# Altium schematic sheet mic_totem_ee-1_Main.SchDoc(mic_totem_ee-1_Main)
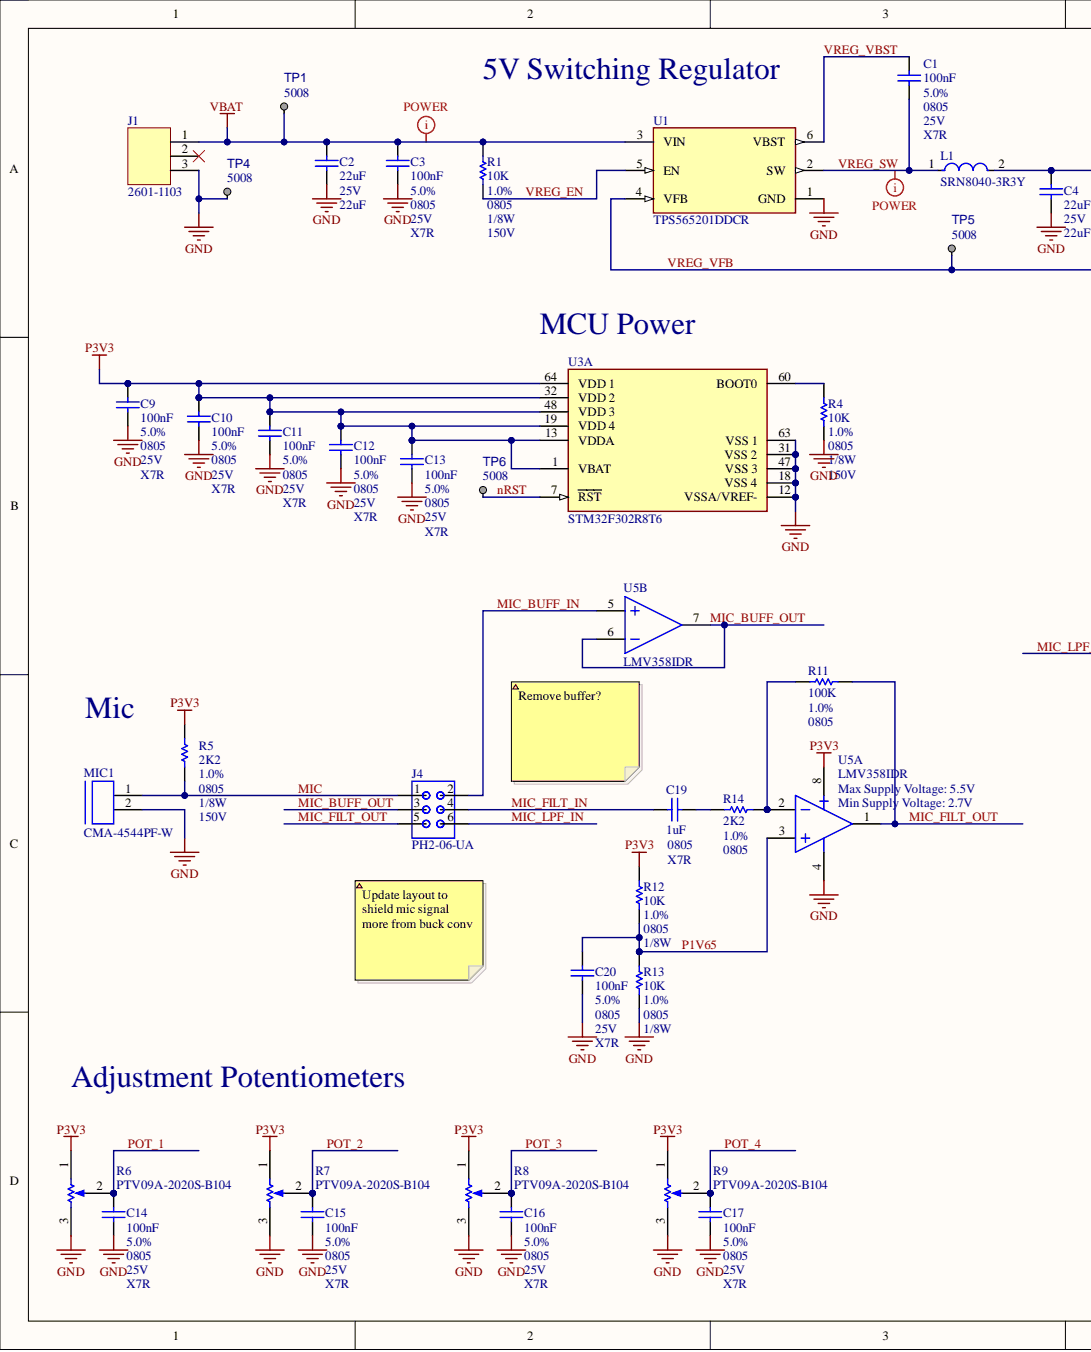
[diagram p0: mic_totem_ee-1_Main.SchDoc, left]
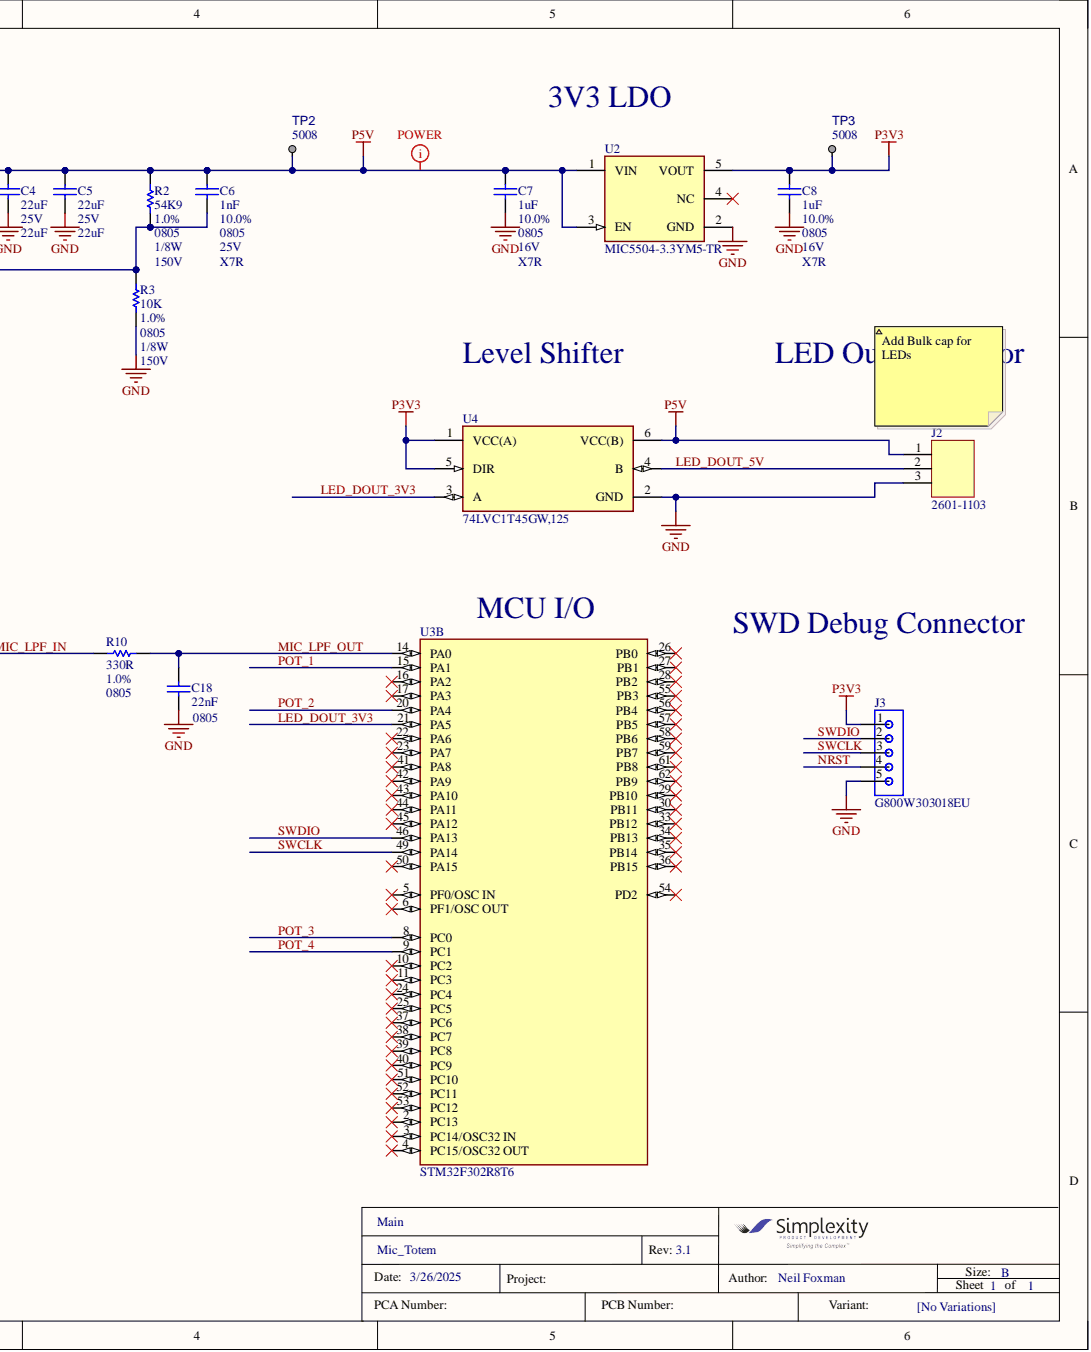
[diagram p1: mic_totem_ee-1_Main.SchDoc, right]

## Parts
C1  100nF  Rated Voltage: 25V  Tolerance: 5.0%  [0805]
C2  22uF  Voltage Rating (DC): 25V  [1206]
C3  100nF  Rated Voltage: 25V  Tolerance: 5.0%  [0805]
C4  22uF  Voltage Rating (DC): 25V  [1206]
C5  22uF  Voltage Rating (DC): 25V  [1206]
C6  1nF  Rated Voltage: 25V  Tolerance: 10.0%  [0805]
C7  1uF  Rated Voltage: 16V  Tolerance: 10.0%  [0805]
C8  1uF  Rated Voltage: 16V  Tolerance: 10.0%  [0805]
C9  100nF  Rated Voltage: 25V  Tolerance: 5.0%  [0805]
C10  100nF  Construction: X7R  Rated Voltage: 25V  Tolerance: 5.0%  [0805]
C11  100nF  Construction: X7R  Rated Voltage: 25V  Tolerance: 5.0%  [0805]
C12  100nF  Construction: X7R  Rated Voltage: 25V  Tolerance: 5.0%  [0805]
C13  100nF  Construction: X7R  Rated Voltage: 25V  Tolerance: 5.0%  [0805]
C14  100nF  Rated Voltage: 25V  Tolerance: 5.0%  [0805]
C15  100nF  Rated Voltage: 25V  Tolerance: 5.0%  [0805]
C16  100nF  Rated Voltage: 25V  Tolerance: 5.0%  [0805]
C17  100nF  Rated Voltage: 25V  Tolerance: 5.0%  [0805]
C18  22nF  [0805]
C19  1uF  [0805]
C20  100nF  Rated Voltage: 25V  Tolerance: 5.0%  [0805]
J1  2601-1103  [2601-1103]
J2  2601-1103  [2601-1103]
J3  G800W303018EU  [CONN_G800W303018EU]
J4  PH2-06-UA  [3x2_100mil]
L1  SRN8040-3R3Y  [FP-SRN8040-MFG]
MIC1  CMA-4544PF-W  [CMA-4544PF-W-Footprint-1]
R1  10K  Power Rating: 1/8W  Rated Voltage: 150V  Tolerance: 1.0%  [0805]
R2  54K9  Power Rating: 1/8W  Tolerance: 1.0%  [0805]
R3  10K  Power Rating: 1/8W  Rated Voltage: 150V  Tolerance: 1.0%  [0805]
R4  10K  Power Rating: 1/8W  Rated Voltage: 150V  Tolerance: 1.0%  [0805]
R5  2K2  Power Rating: 1/8W  Rated Voltage: 150V  Tolerance: 1.0%  [0805]
R6  PTV09A-2020S-B104  [PTV09A-2020S-B104]
R7  PTV09A-2020S-B104  [PTV09A-2020S-B104]
R8  PTV09A-2020S-B104  [PTV09A-2020S-B104]
R9  PTV09A-2020S-B104  [PTV09A-2020S-B104]
R10  330R  Tolerance: 1.0%  [0805]
R11  100K  Tolerance: 1.0%  [0805]
R12  10K  Tolerance: 1.0%  [0805]
R13  10K  Power Rating: 1/8W  Tolerance: 1.0%  [0805]
R14  2K2  Tolerance: 1.0%  [0805]
TP1  5008  [TP_KEYSTONE_COMPACT_ORANGE]
TP2  5008  [TP_KEYSTONE_COMPACT_ORANGE]
TP3  5008  [TP_KEYSTONE_COMPACT_ORANGE]
TP4  5008  [TP_KEYSTONE_COMPACT_ORANGE]
TP5  5008  [TP_KEYSTONE_COMPACT_ORANGE]
TP6  5008  [TP_KEYSTONE_COMPACT_ORANGE]
U1  TPS565201DDCR  [FP-DDC0006A-MFG]
U2  MIC5504-3.3YM5-TR  [FP-SOT23-5LD-PL-1-MFG]
U3  STM32F302R8T6  [FP-LQFP64-5W-IPC_A]  (parts A,B)
U4  74LVC1T45GW,125  [FP-SOT363-IPC_B]
U5  LMV358IDR  [SOIC-8]  (parts A,B)

## Nets
GND: C2.1 C3.1 C4.1 C5.1 C7.1 C8.1 C9.1 C10.1 C11.1 C12.1 C13.1 C14.1 C15.1 C16.1 C17.1 C18.1 C20.1 J1.3 J2.3 J3.5 MIC1.2 R3.1 R4.1 R6.3 R7.3 R8.3 R9.3 R13.1 TP4.1 U1.1(GND) U2.2(GND) U3.12(VSSA/VREF-) U3.18(VSS_4) U3.31(VSS_2) U3.47(VSS_3) U3.63(VSS_1) U4.2(GND) U5.4
P3V3: C8.2 C9.2 C10.2 C11.2 C12.2 C13.2 J3.1 R5.2 R6.1 R7.1 R8.1 R9.1 R12.2 TP3.1 U2.5(VOUT) U3.1(VBAT) U3.13(VDDA) U3.19(VDD_4) U3.32(VDD_2) U3.48(VDD_3) U3.64(VDD_1) U4.1(VCC(A)) U4.5(DIR) U5.8
P5V: C4.2 C5.2 C6.2 C7.2 J2.1 L1.2 R2.2 TP2.1 U2.1(VIN) U2.3(EN) U4.6(VCC(B))
VBAT: C2.2 C3.2 J1.1 R1.2 TP1.1 U1.3(VIN)
LED_DOUT_3V3: U3.21(PA5) U4.3(A)
LED_DOUT_5V: J2.2 U4.4(B)
MIC: J4.1 MIC1.1 R5.1
MIC_BUFF_IN: J4.2 U5.5
MIC_BUFF_OUT: J4.3 U5.6 U5.7
MIC_FILT_IN: C19.2 J4.4
MIC_FILT_OUT: J4.5 R11.2 U5.1
MIC_LPF_IN: J4.6 R10.1
MIC_LPF_OUT: C18.2 R10.2 U3.14(PA0)
nRST: J3.4 TP6.1 U3.7(RST)
P1V65: C20.2 R12.1 R13.2 U5.3
POT_1: C14.2 R6.2 U3.15(PA1)
POT_2: C15.2 R7.2 U3.20(PA4)
POT_3: C16.2 R8.2 U3.8(PC0)
POT_4: C17.2 R9.2 U3.9(PC1)
SWCLK: J3.3 U3.49(PA14)
SWDIO: J3.2 U3.46(PA13)
VREG_EN: R1.1 U1.5(EN)
VREG_SW: C1.1 L1.1 U1.2(SW)
VREG_VBST: C1.2 U1.6(VBST)
VREG_VFB: C6.1 R2.1 R3.2 TP5.1 U1.4(VFB)
NetC19_1: C19.1 R14.2
NetR4_2: R4.2 U3.60(BOOT0)
NetR11_1: R11.1 R14.1 U5.2

Not connected: J1 2; U2 4(NC); U3 2(PC13) 3(PC14/OSC32_IN) 4(PC15/OSC32_OUT) 5(PF0/OSC_IN) 6(PF1/OSC_OUT) 10(PC2) 11(PC3) 16(PA2) 17(PA3) 22(PA6) 23(PA7) 24(PC4) 25(PC5) 26(PB0) 27(PB1) 28(PB2) 29(PB10) 30(PB11) 33(PB12) 34(PB13) 35(PB14) 36(PB15) 37(PC6) 38(PC7) 39(PC8) 40(PC9) 41(PA8) 42(PA9) 43(PA10) 44(PA11) 45(PA12) 50(PA15) 51(PC10) 52(PC11) 53(PC12) 54(PD2) 55(PB3) 56(PB4) 57(PB5) 58(PB6) 59(PB7) 61(PB8) 62(PB9)

## Library data of the parts
C1 C3 C9-C17 C20 = CC0805JRX7R8BB104: 100nF Capacitor, 5.0%, 0805, 25V, X7R; Manufacturer 1: Yageo; Database Table Name: Sheet1$; Component Kind: Standard; Library Name: Passives.DbLib; Manufacturer Part Number 1: CC0805JRX7R8BB104; Package / Case: 0805; Series: E6; Value: 100nF
  C1 C3 C9 C14-C17 C20: Construction: X7R
C2 C4 C5 = CAP_CC1206KFX5R8BB226: 22 F 10% 25V Ceramic Capacitor X5R 1206 (3216 Metric); Manufacturer 1: Yageo; Dielectric: 1537DielectricType; Case/Package: 340Case; Category: Ceramic Capacitors; Component Kind: Standard; Lead Free: 5697LeadFree; Manufacturer Part Number 1: CC1206KFX5R8BB226; Pricing 1: 1=0.7, 10=0.422, 50=0.3122, 100=0.278, 500=0.21966, 1000=0.20145, 2500=0.1821 (USD); RoHS: No; Stock 1: 5915; Supplier 1: Digikey; Supplier Part Number 1: 13-CC1206KFX5R8BB226CT-ND; Value: 22uF; Library Name: mic_totem_ee.SchLib
C6 = CC0805KRX7R8BB102: 1nF Capacitor, 10.0%, 0805, 25V, X7R; Manufacturer 1: Yageo; Database Table Name: Sheet1$; Component Kind: Standard; Construction: X7R; Library Name: Passives.DbLib; Manufacturer Part Number 1: CC0805KRX7R8BB102; Package / Case: 0805; Series: E6; Value: 1nF
C7 C8 C19 = CC0805KKX7R7BB105: 1uF Capacitor, 10.0%, 0805, 16V, X7R; Manufacturer 1: Yageo; Database Table Name: Sheet1$; Component Kind: Standard; Construction: X7R; Library Name: Passives.DbLib; Manufacturer Part Number 1: CC0805KKX7R7BB105; Package / Case: 0805; Series: E6; Value: 1uF
  C19: Rated Voltage: 16V; Tolerance: 10.0%
C18 = CC0805KRX7R9BB223: 22nF Capacitor, 10.0%, 0805, 50V, X7R; Manufacturer: Yageo; Database Table Name: Sheet1$; Component Kind: Standard; Construction: X7R; Library Name: Passives.DbLib; Manufacturer Part Number: CC0805KRX7R9BB223; Package / Case: 0805; Rated Voltage: 50V; Series: E6; Tolerance: 10.0%; Value: 22nF
J1 J2 = 2601-1103: PCB terminal block, lever 1.5 mm² Pin spacing 3.5 mm 3-pole; gray; Manufacturer 1: WAGO; Category: Uncategorized; Component Kind: Standard; Manufacturer Part Number 1: 2601-1103; Pricing 1: 1=2.33, 25=2.19, 100=2.06, 250=1.94, 500=1.79 (USD); RoHS: No; Stock 1: 2; Supplier 1: Newark; Supplier Part Number 1: 59AK0745; Library Name: mic_totem_ee.SchLib
J3 = CONN_G800W303018EU: Board to Board Connector Straight Header Single Row 5 Position 2.54mm Pitch Through Hole Bag; Manufacturer 1: Amphenol; Category: Connectors; Component Kind: Standard; Manufacturer Part Number 1: G800W303018EU; Pricing 1: 1=0.105, 10=0.094, 25=0.084, 50=0.073, 100=0.067 (USD); RoHS: Yes; RoHS Compliant: Yes; Stock 1: 20166; Supplier 1: Avnet; Supplier Part Number 1: 89AH5887; Library Name: mic_totem_ee.SchLib
J4 = CONN_PH2-06-UA: Board Connector, 6 Contact(s), 2 Row(s), Male, Straight, 0.1 inch Pitch, Solder Terminal, Black Insulator, Receptacle; Manufacturer 1: Adam Tech; Additional Feature: ROHS COMPLIANT; Body Breadth: 0.197inch; Body Depth: 0.098inch; Body Length: 0.3inch; Contact Pattern: RECTANGULAR; Contact Resistance: 20mR; Contact Style: SQ PIN-SKT; Dielectric Withstanding Voltage (AC): 1kV; DIN Conformance: No; Durability: 1000Cycles; Insulation Resistance: 5GR; Number Of Connectors: 1; Number of Contacts: 6; Number Of PCB Rows: 2; Option: General Purpose; PCB Contact Row Spacing: 2.54mm; Rated Current (Signal): 3A; Reference Standard: UL; Reliability: COMMERCIAL; Body/Shell Style: RECEPTACLE; Category: Connectors; Component Kind: Standard; ComponentLink1Description: https://datasheet.ciiva.com:443/pdfs/VipMasterIC/IC/ADMT/ADMT-D-A0000799240/ADMT-D-A0000799340-1.pdf?src-supplier=IHS+Markit; ComponentLink1URL: https://datasheet.ciiva.com:443/pdfs/VipMasterIC/IC/ADMT/ADMT-D-A0000799240/ADMT-D-A0000799340-1.pdf?src-supplier=IHS+Markit; ComponentLink2Description: https://datasheet.octopart.com/PH2-06-UA-Adam-Tech-datasheet-12339966.pdf?src-supplier=Future+Electronics; ComponentLink2URL: https://datasheet.octopart.com/PH2-06-UA-Adam-Tech-datasheet-12339966.pdf?src-supplier=Future+Electronics; Connector Type: BOARD CONNECTOR; Contact Finish - Mating: AU ON NI; Contact Finish - Termination: Gold (Au) - with Nickel (Ni) barrier; Contact Gender: Male; Contact Material: Brass; Filter Feature: No; Flammability Rating: UL94 V-0; IEC Conformance: No; Insulation Color: Black; Insulation Material: Glass; JESD-609 Code: e4; Manufacturer Part Number 1: PH2-06-UA; Mating Contact Pitch: 0.1inch; Mating Contact Row Spacing: 0.1inch; Max Operating Temperature: 105°C; MIL Conformance: No; Min Operating Temperature: -40°C; Mixed Contacts: No; Mounting Style: STRAIGHT; Mounting Technology: Board; Number of Rows Loaded: 2; PCB Contact Pattern: RECTANGULAR; Pricing 1: 1=0.1, 10=0.068, 100=0.0557, 500=0.04824, 1000=0.04537, 5000=0.03933, 10000=0.03698 (USD); Reach SVHC Compliant: Yes; RoHS: Yes; RoHS Compliant: Yes; Stock 1: 19072; Supplier 1: Digikey; Supplier Part Number 1: 2057-PH2-06-UA-ND; Terminal Length: 0.12inch; Terminal Pitch: 2.54mm; Termination: Solder; Library Name: mic_totem_ee.SchLib
L1 = CMP-07248-000074-1: FIXED IND 3.3UH 5.6A 21 MOHM SMD; Core Material: Ferrite; DC Resistance (DCR): 21mR; Inductance Tolerance: 30%; Material: Steel; Sample Rate (Hz): 100kHz; Saturation Current: 5.5A; Self Resonant Frequency: 34MHz; Shielding: Shielded; Case/Package: Nonstandard; Component Kind: Standard; Current Rating: 5.6A; DC Current: 5.6A; Depth: 8.3mm; Height: 4mm; Height - Seated (Max): 0.157inch; Inductance: 3.3uH; Length: 8mm; Library Name: Altium Content Vault; Max DC Current: 5.6A; Max Operating Temperature: 125°C; Military Standard: Not; Min Operating Temperature: -40°C; Mount: Surface Mount; Number of Pins: 2; Package Quantity: 1000; Packaging: Tape and Reel; REACH SVHC: No SVHC; Resistor Type: Wirewound; RMS Current (Irms): 5.6A; RoHS Compliant: Yes; Series: SRN8040; Termination: SMD/SMT; Test Frequency: 100kHz; Tolerance: 30%; Width: 8mm
MIC1 = CMP-052-000000-3: CMA Series 9.7 mm Electret Condenser Omni-Directional Pin Mount 3 V Microphone; Component Kind: Standard; Library Name: SimplexityPD
R1 R3 R4 = RC0805FR-0710KL: 10K Resistor, 1.0%, 0805, 1/8W; Manufacturer 1: Yageo; Database Table Name: Sheet1$; Component Kind: Standard; Construction: Thick Film; Library Name: Passives.DbLib; Manufacturer Part Number 1: RC0805FR-0710KL; Package / Case: 0805; Series: E24, E96; Value: 10K
R2 = RC0805FR-0754K9L: 54K9 Resistor, 1.0%, 0805, 1/8W; Manufacturer 1: Yageo; Database Table Name: Sheet1$; Rated Voltage: 150V; Component Kind: Standard; Construction: Thick Film; Library Name: Passives.DbLib; Manufacturer Part Number 1: RC0805FR-0754K9L; Package / Case: 0805; Series: E96; Value: 54K9
R5 = RC0805FR-072K2L: 2K2 Resistor, 1.0%, 0805, 1/8W; Manufacturer 1: Yageo; Database Table Name: Sheet1$; Component Kind: Standard; Construction: Thick Film; Library Name: Passives.DbLib; Manufacturer Part Number 1: RC0805FR-072K2L; Package / Case: 0805; Series: E24; Value: 2K2
R6-R9 = PTV09A-2020S-B104: POT 100K OHM 1/20W CARBON LINEAR; Component Kind: Standard; Library Name: mic_totem_ee.SchLib
R10 = RC0805FR-07330RL: 330R Resistor, 1.0%, 0805, 1/8W; Manufacturer: Yageo; Database Table Name: Sheet1$; Rated Voltage: 150V; Component Kind: Standard; Construction: Thick Film; Library Name: Passives.DbLib; Manufacturer Part Number: RC0805FR-07330RL; Package / Case: 0805; Power Rating: 1/8W; Series: E24; Value: 330R
R11 = RC0805FR-07100KL: 100K Resistor, 1.0%, 0805, 1/8W; Manufacturer: Yageo; Database Table Name: Sheet1$; Rated Voltage: 150V; Component Kind: Standard; Construction: Thick Film; Library Name: Passives.DbLib; Manufacturer Part Number: RC0805FR-07100KL; Package / Case: 0805; Power Rating: 1/8W; Series: E24, E96; Value: 100K
R12 R13 = RC0805FR-0710KL: 10K Resistor, 1.0%, 0805, 1/8W; Manufacturer 1: Yageo; Database Table Name: Sheet1$; Rated Voltage: 150V; Component Kind: Standard; Construction: Thick Film; Library Name: Passives.DbLib; Manufacturer Part Number 1: RC0805FR-0710KL; Package / Case: 0805; Series: E24, E96; Value: 10K
  R12: Power Rating: 1/8W
R14 = RC0805FR-072K2L: 2K2 Resistor, 1.0%, 0805, 1/8W; Manufacturer 1: Yageo; Database Table Name: Sheet1$; Rated Voltage: 150V; Component Kind: Standard; Construction: Thick Film; Library Name: Passives.DbLib; Manufacturer Part Number 1: RC0805FR-072K2L; Package / Case: 0805; Power Rating: 1/8W; Series: E24; Value: 2K2
TP1-TP6 = 30836: PC TEST POINT COMPACT ORANGE TH; Manufacturer 1: Keystone Electronics; Database Table Name: parts; Sub_Type: COMP; Updated By: DaveHough; Component Kind: Standard; ComponentLink1Description: Datasheet; ComponentLink1URL: http://www.keyelco.com/product-pdf.cfm?p=1353; ComponentLink2Description: Supplier Part Details; ComponentLink2URL: https://www.digikey.com/products/en?keywords=5008; Created_Date: 2023-03-16 13:16:15; ID: 30836; Library Name: Parts.DbLib; LifeCycle: New; Manufacturer Part Number 1: 5008; Mfr Part Description: PC TEST POINT COMPACT ORANGE TH; Part_Type: TEST; RoHS: Yes; Supplier: Digi-Key; Supplier Part Number: 36-5008-ND; Updated_Date: 2023-03-16 15:06:36; Value: 5008; Created By: DaveHough
U1 = CMP-04918-000352-1: IC REG BUCK ADJ 5A TSOT23-6; Max Input Voltage: 17V; Max Output Voltage: 7V; Min Input Voltage: 4.5V; Min Output Voltage: 600mV; Number of Outputs: 1; Output Configuration: Positive; Output Type: Adjustable; Switching Frequency: 500kHz; Synchronous Rectifier: Yes; Case/Package: SOT-23-6; Component Kind: Standard; Library Name: Altium Content Vault; Max Operating Temperature: 125°C; Min Operating Temperature: -40°C; Mount: Surface Mount; Output Current: 5A; Packaging: Tape and Reel; RoHS Compliant: Yes
U2 = CMP-2000-07604-2: IC REG LINEAR 3.3V 300MA SOT23-5; Accuracy: 3%; Dropout Voltage: 380mV; Max Input Voltage: 5.5V; Min Input Voltage: 2.5V; Number of Outputs: 1; Operating Supply Current: 42uA; Output Type: Fixed; Power Supply Rejection Ratio (PSRR): 60dB; Quiescent Current: 38uA; Case/Package: SOT-23-5; Component Kind: Standard; Height: 1.1mm; Length: 2.9mm; Library Name: Altium Content Vault; Max Operating Temperature: 125°C; Max Output Current: 300mA; Min Operating Temperature: -40°C; Mount: Surface Mount; Nominal Output Voltage: 3.3V; Number of Pins: 5; Output Current: 300mA; Output Voltage: 3.3V; Packaging: Tape and Reel; REACH SVHC: No SVHC; Width: 1.6mm
U3 = CMP-0537-00018-2: IC MCU 32BIT 64KB FLASH 64LQFP; Core Architecture: ARM; Data Bus Width: 32b; Frequency: 72MHz; Interface: I2C; Max Power Dissipation: 444mW; Max Supply Voltage: 3.6V; Min Supply Voltage: 2V; Number of ADC Channels: 11; Number of I/Os: 51; Number of Timers/Counters: 9; Oscillator Type: Internal; Peripherals: POR; RAM Size: 16KB; Watchdog Timer: Yes; Case/Package: LQFP; Component Kind: Standard; Library Name: Altium Content Vault; Max Frequency: 72MHz; Max Operating Temperature: 85°C; Memory Size: 64KB; Memory Type: FLASH; Min Operating Temperature: -40°C; Number of Pins: 64; Number of Programmable I/O: 51; Package Quantity: 960; Packaging: Tray; Radiation Hardening: No; REACH SVHC: No SVHC; RoHS Compliant: Yes; Weight: 0.012088oz
U4 = CMP-14347-000008-1: IC TRNSLTR BIDIRECTIONAL 6TSSOP; Component Kind: Standard; Library Name: Altium Content Vault
U5 = LMV358IDR: Dual, 5.5-V, 1-MHz, RRO operational amplifier 8-SOIC -40 to 125; Manufacturer: Texas Instruments; Amplifier Type: GENERAL PURPOSE; Bandwidth: 1MHz; Common Mode Rejection Ratio: 50dB; Input Bias Current: 250nA; Input Offset Voltage (Vos): 7mV; Max Supply Voltage: 5.5V; Min Supply Voltage: 2.7V; Number of Amplifiers: 2; Operating Supply Current: 210uA; Output Current: 160mA; Slew Rate: 1V/µs; Supply Current: 440uA; Voltage Gain: 100dB; Case/Package: SOIC; Category: Amplifiers - Op Amps, Buffer, Instrumentation; Component Kind: Standard; ComponentLink1Description: https://datasheet.ciiva.com/34302/1348922-34302239.pdf?src-supplier=Jameco; ComponentLink1URL: https://datasheet.ciiva.com/34302/1348922-34302239.pdf?src-supplier=Jameco; ComponentLink2Description: https://datasheet.ciiva.com/46392/1888792-46392005.pdf?src-supplier=Element14; ComponentLink2URL: https://datasheet.ciiva.com/46392/1888792-46392005.pdf?src-supplier=Element14; ComponentLink3Description: https://datasheet.ciiva.com/1795/lmv324-1795701.pdf?src-supplier=Digikey; ComponentLink3URL: https://datasheet.ciiva.com/1795/lmv324-1795701.pdf?src-supplier=Digikey; ComponentLink4Description: https://datasheet.ciiva.com/1795/lmv324-1795700.pdf?src-supplier=Digikey; ComponentLink4URL: https://datasheet.ciiva.com/1795/lmv324-1795700.pdf?src-supplier=Digikey; ComponentLink5Description: https://datasheet.ciiva.com/1795/lmv324-1795702.pdf?src-supplier=Digikey; ComponentLink5URL: https://datasheet.ciiva.com/1795/lmv324-1795702.pdf?src-supplier=Digikey; ComponentLink6Description: https://datasheet.ciiva.com/2973/lmv358-2973580.pdf?src-supplier=Avnet; ComponentLink6URL: https://datasheet.ciiva.com/2973/lmv358-2973580.pdf?src-supplier=Avnet; Gain Bandwidth Product: 1MHz; Lead Free: Lead Free; Max Operating Temperature: 125°C; Mfg Part Number: LMV358IDR; Min Operating Temperature: -40°C; Mounting Technology: Surface Mount; Number of Channels: 2; Number of Circuits: 2; Number of Elements: 2; Output Current per Channel: 160mA; Package Quantity: 2500; Packaging: Tape and Reel; Pins: 8; Pricing 1: 1=0.36, 10=0.293, 50=0.293, 100=0.2, 1000=0.112, 2500=0.102, 10000=0.09, 25000=0.083, 50000=0.08, 100000=0.078 (USD); Radiation Hardening: No; Reach SVHC Compliant: No; RoHS: Yes; RoHS Compliant: Yes; Series: -; Stock 1: 26583; Supplier 1: Mouser; Supplier Part Number 1: 595-LMV358IDR; Technology: BICMOS; Unity Gain Bandwidth Product: 1MHz; Library Name: mic_totem_ee.SchLib
